# Altium schematic sheet Power Stuff.SchDoc
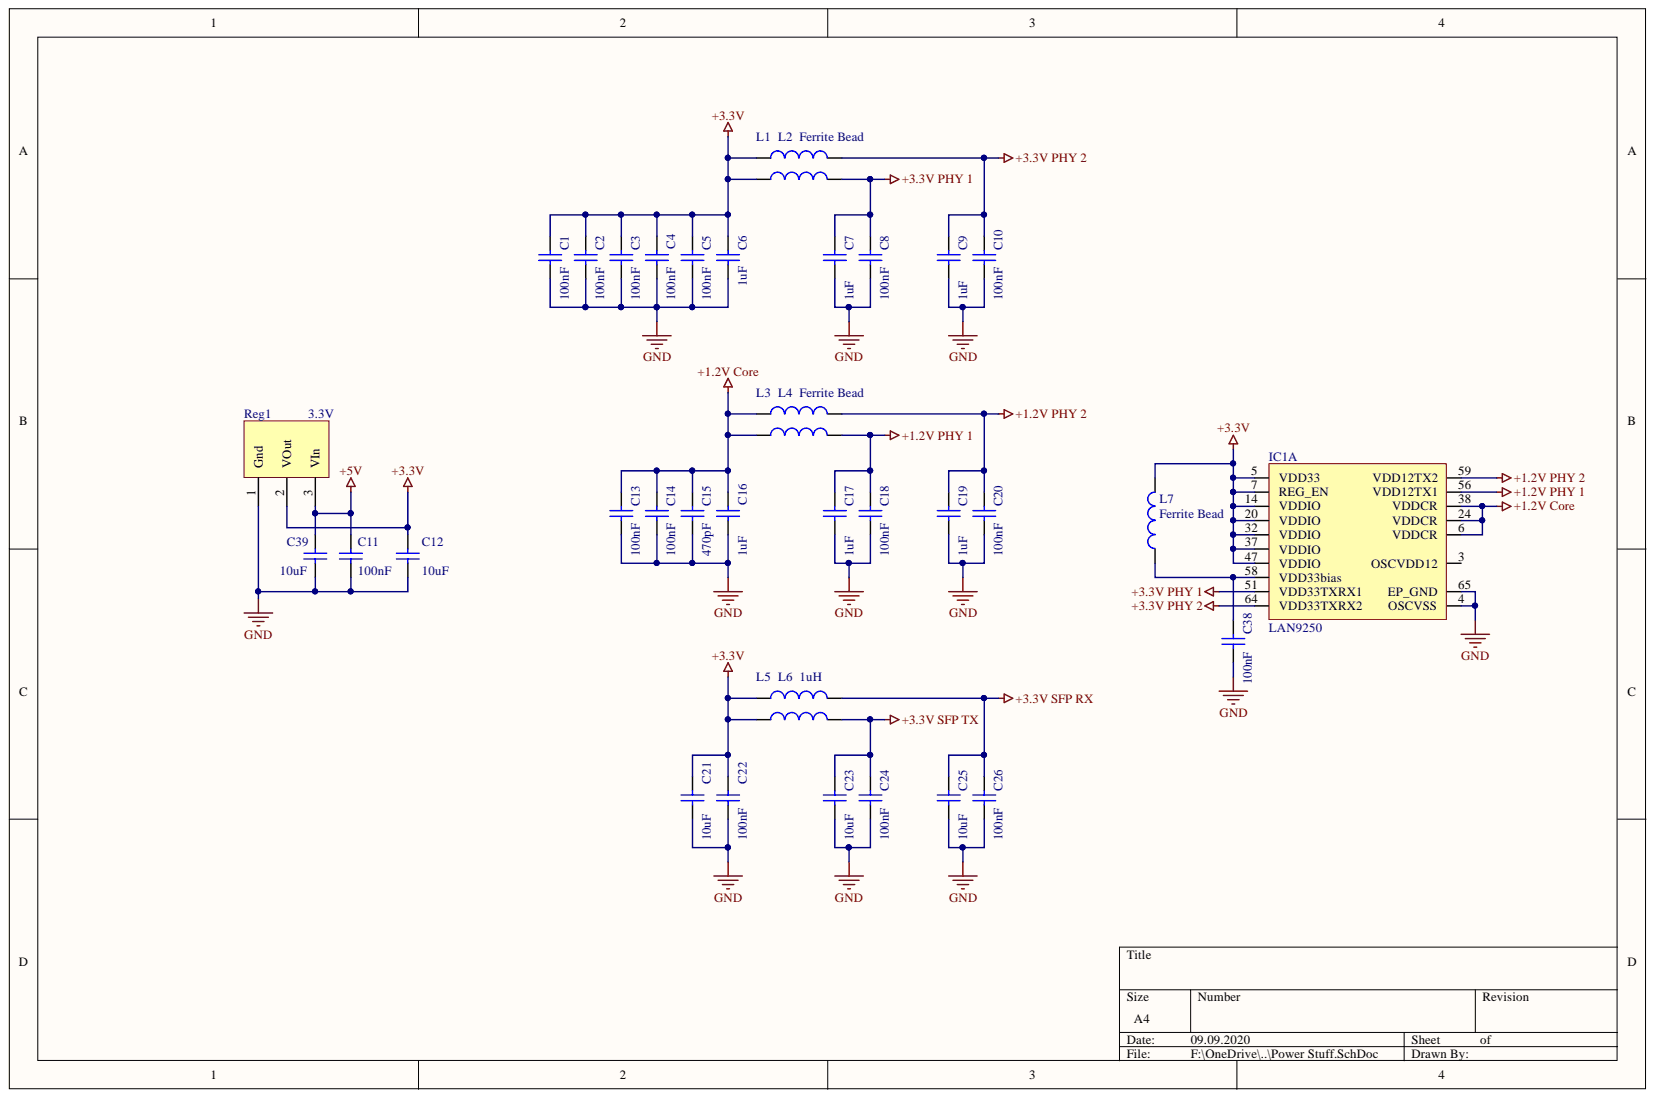
[diagram p0: Power Stuff.SchDoc]

## Parts
C1  100nF  [0603]
C2  100nF  [0603]
C3  100nF  [0603]
C4  100nF  [0603]
C5  100nF  [0603]
C6  1uF  [0603]
C7  1uF  [0603]
C8  100nF  [0603]
C9  1uF  [0603]
C10  100nF  [0603]
C11  100nF  [0603]
C12  10uF  [0603]
C13  100nF  [0603]
C14  100nF  [0603]
C15  470pF  [0603]
C16  1uF  [0603]
C17  1uF  [0603]
C18  100nF  [0603]
C19  1uF  [0603]
C20  100nF  [0603]
C21  10uF  [0603]
C22  100nF  [0603]
C23  10uF  [0603]
C24  100nF  [0603]
C25  10uF  [0603]
C26  100nF  [0603]
C38  100nF  [0603]
C39  10uF  [0603]
IC1  LAN9250  [QFN64]  (part A)
L1  Ferrite Bead  [0603]
L2  Ferrite Bead  [0603]
L3  Ferrite Bead  [0603]
L4  Ferrite Bead  [0603]
L5  1uH  [0603]
L6  1uH  [0603]
L7  Ferrite Bead  [0603]
Reg1  3.3V  [SOT223]

## Nets
+1.2V Core: C13.1 C14.1 C15.1 C16.1 IC1.6(VDDCR) IC1.24(VDDCR) IC1.38(VDDCR) L3.1 L4.1
+1.2V PHY 1: C17.1 C18.1 IC1.56(VDD12TX1) L4.2
+1.2V PHY 2: C19.1 C20.1 IC1.59(VDD12TX2) L3.2
+3.3V: C1.1 C2.1 C3.1 C4.1 C5.1 C6.1 C12.2 C21.1 C22.1 IC1.5(VDD33) IC1.7(REG_EN) IC1.14(VDDIO) IC1.20(VDDIO) IC1.32(VDDIO) IC1.37(VDDIO) IC1.47(VDDIO) L1.1 L2.1 L5.1 L6.1 L7.2 Reg1.2
+3.3V PHY 1: C7.1 C8.1 IC1.51(VDD33TXRX1) L2.2
+3.3V PHY 2: C9.1 C10.1 IC1.64(VDD33TXRX2) L1.2
+3.3V SFP RX: C25.1 C26.1 L5.2
+3.3V SFP TX: C23.1 C24.1 L6.2
+5V: C11.2 C39.2 Reg1.3
GND: C1.2 C2.2 C3.2 C4.2 C5.2 C6.2 C7.2 C8.2 C9.2 C10.2 C11.1 C12.1 C13.2 C14.2 C15.2 C16.2 C17.2 C18.2 C19.2 C20.2 C21.2 C22.2 C23.2 C24.2 C25.2 C26.2 C38.2 C39.1 IC1.4(OSCVSS) IC1.65(EP_GND) Reg1.1
NetC38_1: C38.1 IC1.58(VDD33bias) L7.1

Not connected: IC1 3(OSCVDD12)

## Library data of the parts
C1-C26 C38 C39 = Cap: Component Kind: Standard; Library Name: stdLib.SCHLIB; Supplier 1: Digi-Key; Supplier 2: Digi-Key; Supplier 3: Digi-Key; Supplier 4: Digi-Key; Supplier 5: Digi-Key; Supplier 6: Digi-Key; Supplier 7: Digi-Key; Supplier 8: Digi-Key; Supplier 9: Digi-Key; Supplier 10: Digi-Key; Supplier 11: Digi-Key; Supplier 12: Digi-Key; Supplier 13: Digi-Key; Supplier 14: Digi-Key; Supplier 15: Digi-Key; Supplier 16: Digi-Key; Supplier 17: Digi-Key; Supplier 18: Digi-Key; Supplier 19: Digi-Key; Supplier 20: Digi-Key; Supplier 21: Digi-Key; Supplier 22: Digi-Key; Supplier Part Number 1: 1276-2044-1-ND; Supplier Part Number 2: 1276-1018-1-ND; Supplier Part Number 3: 1276-1182-1-ND; Supplier Part Number 4: 1276-1009-1-ND; Supplier Part Number 5: 1276-1098-1-ND; Supplier Part Number 6: 1276-1119-1-ND; Supplier Part Number 7: 490-12737-1-ND; Supplier Part Number 8: 1276-1183-1-ND; Supplier Part Number 9: 587-1249-1-ND; Supplier Part Number 10: 1276-CL10B104KB8NNNLCT-ND; Supplier Part Number 11: 399-1085-1-ND; Supplier Part Number 12: 1276-1021-1-ND; Supplier Part Number 13: 587-2985-1-ND; Supplier Part Number 14: 490-14603-1-ND; Supplier Part Number 15: 311-3700-1-ND; Supplier Part Number 16: 311-1042-1-ND; Supplier Part Number 17: 311-1415-1-ND; Supplier Part Number 18: 1276-1907-1-ND; Supplier Part Number 19: 587-4321-1-ND; Supplier Part Number 20: 1276-6767-1-ND; Supplier Part Number 21: 1276-7060-1-ND; Supplier Part Number 22: 311-1084-1-ND
IC1 = LAN9250: 10/100 Ethernet controller & PHY; Component Kind: Standard; ComponentLink1Description: Datasheet; ComponentLink1URL: https://ww1.microchip.com/downloads/en/DeviceDoc/00001913A.pdf; Library Name: stdLib.SCHLIB; Supplier 1: Digi-Key; Supplier Part Number 1: LAN9250/ML-ND
L1-L7 = Inductor: Component Kind: Standard; Library Name: stdLib.SCHLIB; Supplier 1: Digi-Key; Supplier 2: Digi-Key; Supplier 3: Digi-Key; Supplier 4: Digi-Key; Supplier 5: Digi-Key; Supplier 6: Digi-Key; Supplier 7: Digi-Key; Supplier 8: Digi-Key; Supplier 9: Digi-Key; Supplier 10: Digi-Key; Supplier 11: Digi-Key; Supplier 12: Digi-Key; Supplier 13: Digi-Key; Supplier Part Number 1: 541-1250-1-ND; Supplier Part Number 2: 541-1253-1-ND; Supplier Part Number 3: SRN6028-3R9MCT-ND; Supplier Part Number 4: SRN6028-4R7MCT-ND; Supplier Part Number 5: 490-10563-1-ND; Supplier Part Number 6: 1276-6932-1-ND; Supplier Part Number 7: 587-2042-1-ND; Supplier Part Number 8: 535-12790-1-ND; Supplier Part Number 9: SRP1265A-1R0MCT-ND; Supplier Part Number 10: SRP1770TA-5R6MCT-ND; Supplier Part Number 11: SRN6028-100MCT-ND; Supplier Part Number 12: SRN2510F-2R2MCT-ND; Supplier Part Number 13: 490-3992-1-ND
Reg1 = VReg: Component Kind: Standard; Library Name: stdLib.SCHLIB
